# Altium schematic sheet 01.SchDoc
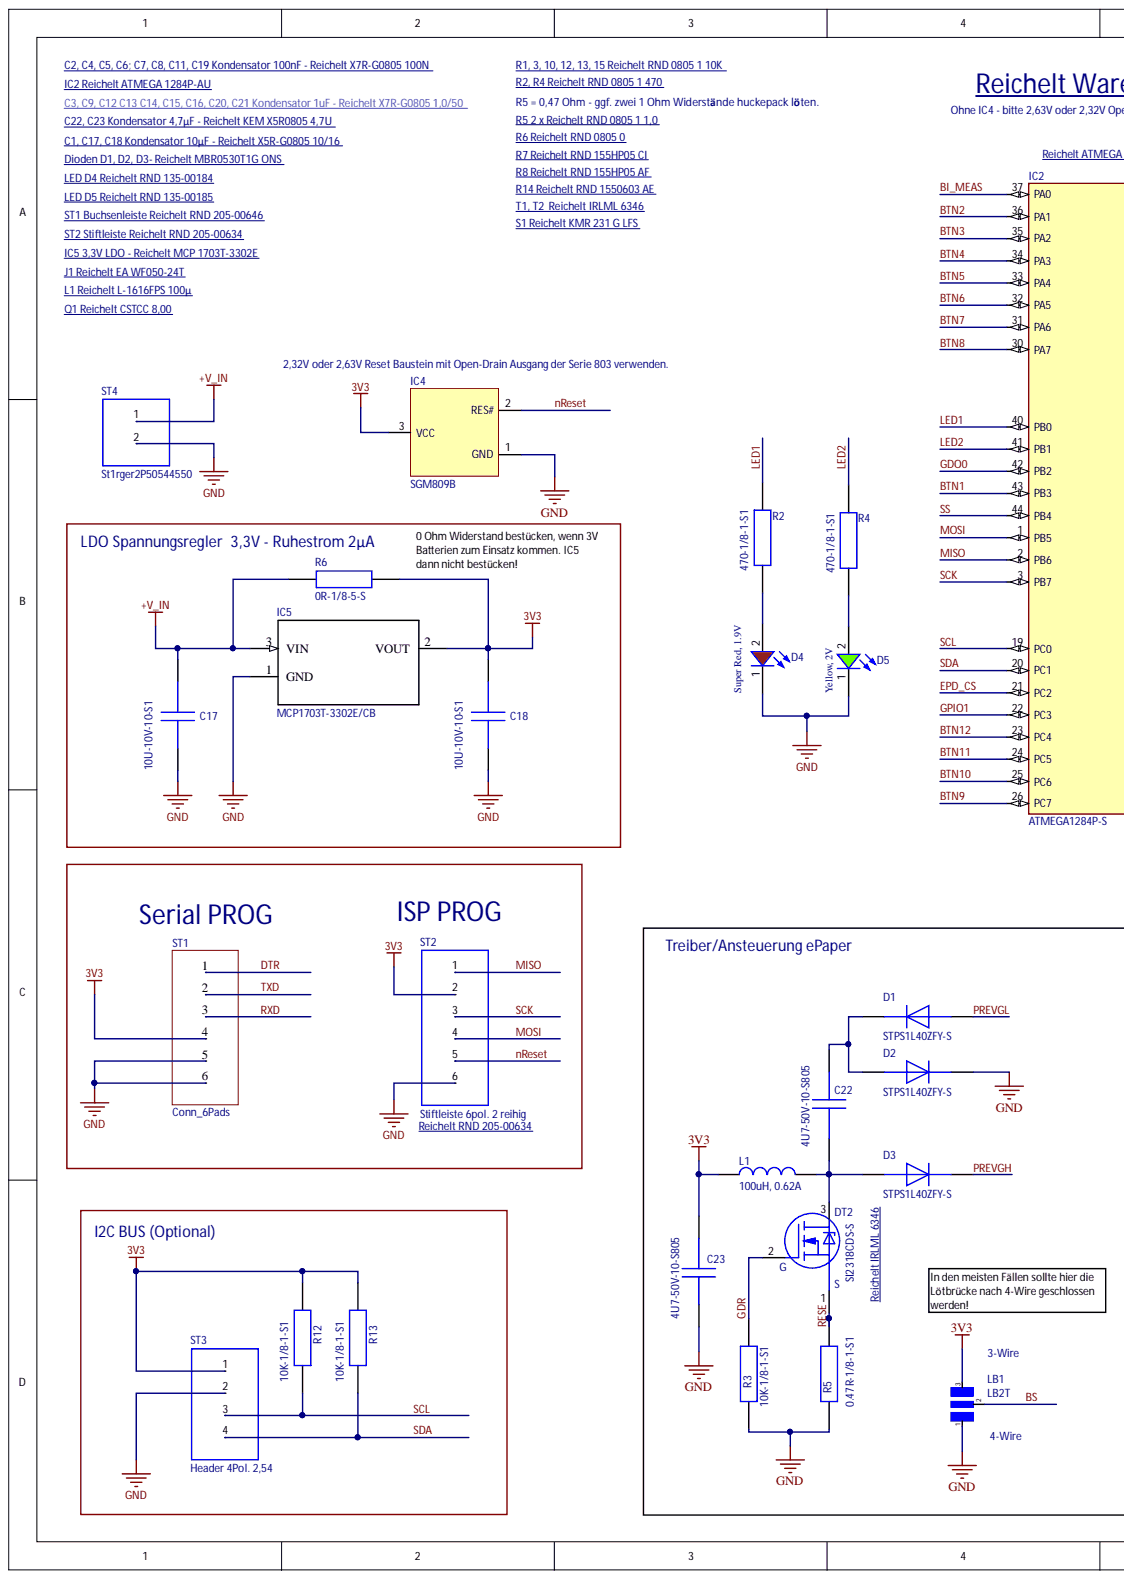
[diagram p0: 01.SchDoc, left]
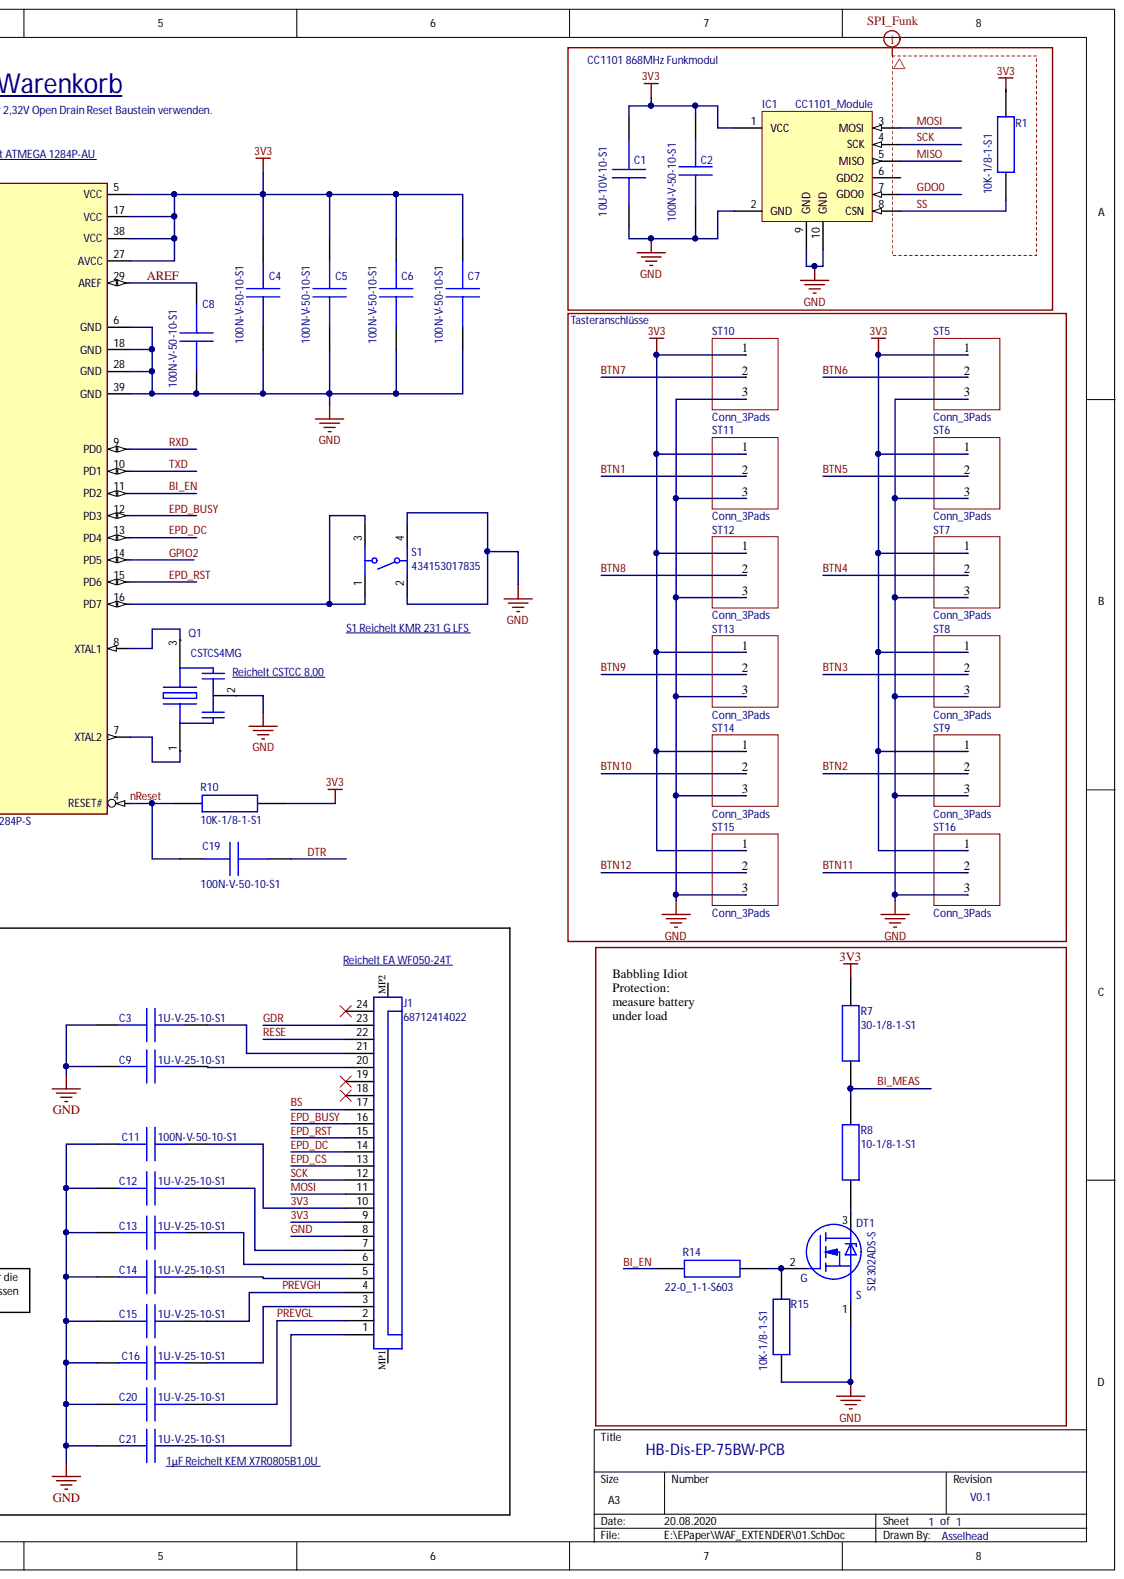
[diagram p1: 01.SchDoc, right]

## Parts
C1  10U-10V-10-S1  [Foot3816A]
C2  100N-V-50-10-S1  [Foot3816A]
C3  1U-V-25-10-S1  [Foot3816A]
C4  100N-V-50-10-S1  [Foot3816A]
C5  100N-V-50-10-S1  [Foot3816A]
C6  100N-V-50-10-S1  [Foot3816A]
C7  100N-V-50-10-S1  [Foot3816A]
C8  100N-V-50-10-S1  [Foot3816A]
C9  1U-V-25-10-S1  [Foot3816A]
C11  100N-V-50-10-S1  [Foot3816A]
C12  1U-V-25-10-S1  [Foot3816A]
C13  1U-V-25-10-S1  [Foot3816A]
C14  1U-V-25-10-S1  [Foot3816A]
C15  1U-V-25-10-S1  [Foot3816A]
C16  1U-V-25-10-S1  [Foot3816A]
C17  10U-10V-10-S1  [Foot3816A]
C18  10U-10V-10-S1  [Foot3816A]
C19  100N-V-50-10-S1  [Foot3816A]
C20  1U-V-25-10-S1  [Foot3816A]
C21  1U-V-25-10-S1  value 1µF  [Foot3816A]
C22  4U7-50V-10-S805  [0805_Capacitor 1,8mm Height]
C23  4U7-50V-10-S805  [0805_Capacitor 1,8mm Height]
D1  STPS1L40ZFY-S  [FootSOD123FL]
D2  STPS1L40ZFY-S  [FootSOD123FL]
D3  STPS1L40ZFY-S  [FootSOD123FL]
D4  Super Red, 1.9V  Forward Voltage typ.: 1.9V  [WL-SMCW_0805]
D5  Yellow, 2V  Forward Voltage typ.: 2V  [WL-SMCW_0805]
IC1  CC1101_Module  [CC1101_Module]
IC2  ATMEGA1284P-S  [Foot-TQFP44]
IC4  SGM809B  [Foot555A]
IC5  MCP1703T-3302E/CB  [SOT23-3]
J1  68712414022  [68712414022]
L1  100uH, 0.62A  Rated Current: 0.62A  [WE-LQS_5020]
LB1  LB2T  [Foot-LB2-0603]
Q1  CSTCS4MG  [Foot5411A]
R1  10K-1/8-1-S1  [Foot4417A]
R2  470-1/8-1-S1  [Foot4417A]
R3  10K-1/8-1-S1  [Foot4417A]
R4  470-1/8-1-S1  [Foot4417A]
R5  0.47R-1/8-1-S1  [Foot4417A]
R6  0R-1/8-5-S  value 0  [Foot4417A]
R7  30-1/8-1-S1  [Foot4417A]
R8  10-1/8-1-S1  [Foot4417A]
R10  10K-1/8-1-S1  [Foot4417A]
R12  10K-1/8-1-S1  [Foot4417A]
R13  10K-1/8-1-S1  [Foot4417A]
R14  22-0_1-1-S603  [Foot_Resistor_0603]
R15  10K-1/8-1-S1  [Foot4417A]
S1  434153017835  [434153017835]
ST1  Conn_6Pads  [Board_Contact6P]
ST2  Stiftleiste 6pol. 2 reihig  [Foot7387A]
ST3  Header 4Pol. 2,54  Pitch: 2,54  [Foot7331A]
ST4  St1rger2P50544550  [Foot7330A]
ST5  Conn_3Pads  [BOARD_CONTACT3P]
ST6  Conn_3Pads  [BOARD_CONTACT3P]
ST7  Conn_3Pads  [BOARD_CONTACT3P]
ST8  Conn_3Pads  [BOARD_CONTACT3P]
ST9  Conn_3Pads  [BOARD_CONTACT3P]
ST10  Conn_3Pads  [BOARD_CONTACT3P]
ST11  Conn_3Pads  [BOARD_CONTACT3P]
ST12  Conn_3Pads  [BOARD_CONTACT3P]
ST13  Conn_3Pads  [BOARD_CONTACT3P]
ST14  Conn_3Pads  [BOARD_CONTACT3P]
ST15  Conn_3Pads  [BOARD_CONTACT3P]
ST16  Conn_3Pads  [BOARD_CONTACT3P]
T1  SI2302ADS-S  [Foot8309A]
T2  SI2318CDS-S  [Foot8309A]

## Nets
+V_IN: C17.2 IC5.3 R6.1 ST4.1
3V3: C1.2 C2.1 C4.1 C5.1 C6.1 C7.1 C11.2 C18.2 C23.2 IC1.1(VCC) IC2.5(VCC) IC2.17(VCC) IC2.27(AVCC) IC2.38(VCC) IC4.3 IC5.2 J1.9 J1.10 L1.1 LB1.3 R1.2 R6.2 R7.2 R10.2 R12.2 R13.2 ST1.4 ST2.2 ST3.1 ST5.1 ST6.1 ST7.1 ST8.1 ST9.1 ST10.1 ST11.1 ST12.1 ST13.1 ST14.1 ST15.1 ST16.1
AREF: C8.1 IC2.29(AREF)
BI_EN: IC2.11(PD2) R14.1
BI_MEAS: IC2.37(PA0) R7.1 R8.2
BS: J1.17 LB1.2
BTN1: IC2.43(PB3) ST11.2
BTN2: IC2.36(PA1) ST9.2
BTN3: IC2.35(PA2) ST8.2
BTN4: IC2.34(PA3) ST7.2
BTN5: IC2.33(PA4) ST6.2
BTN6: IC2.32(PA5) ST5.2
BTN7: IC2.31(PA6) ST10.2
BTN8: IC2.30(PA7) ST12.2
BTN9: IC2.26(PC7) ST13.2
BTN10: IC2.25(PC6) ST14.2
BTN11: IC2.24(PC5) ST16.2
BTN12: IC2.23(PC4) ST15.2
DTR: C19.2 ST1.1
EPD_BUSY: IC2.12(PD3) J1.16
EPD_CS: IC2.21(PC2) J1.13
EPD_DC: IC2.13(PD4) J1.14
EPD_RST: IC2.15(PD6) J1.15
GDO0: IC1.7(GDO0) IC2.42(PB2)
GDR: J1.23 R3.1 T2.2
GND: C1.1 C2.2 C3.1 C4.2 C5.2 C6.2 C7.2 C8.2 C9.1 C11.1 C12.1 C13.1 C14.1 C15.1 C16.1 C17.1 C18.1 C20.1 C21.1 C23.1 D2.1 D4.1 D5.1 IC1.2(GND) IC1.9(GND) IC1.10(GND) IC2.6(GND) IC2.18(GND) IC2.28(GND) IC2.39(GND) IC4.1 IC5.1 J1.8 LB1.1 Q1.2 R3.2 R5.1 R15.1 S1.2 S1.4 ST1.5 ST1.6 ST2.6 ST3.2 ST4.2 ST5.3 ST6.3 ST7.3 ST8.3 ST9.3 ST10.3 ST11.3 ST12.3 ST13.3 ST14.3 ST15.3 ST16.3 T1.1
GPIO1: IC2.22(PC3)
GPIO2: IC2.14(PD5)
LED1: IC2.40(PB0) R2.1
LED2: IC2.41(PB1) R4.1
MISO: IC1.5(MISO) IC2.2(PB6) ST2.1
MOSI: IC1.3(MOSI) IC2.1(PB5) J1.11 ST2.4
nReset: C19.1 IC2.4(RESET#) IC4.2 R10.1 ST2.5
PREVGH: C15.2 D3.1 J1.4
PREVGL: C20.2 D1.2 J1.2
RESE: J1.22 R5.2 T2.1
RXD: IC2.9(PD0) ST1.3
SCK: IC1.4(SCK) IC2.3(PB7) J1.12 ST2.3
SCL: IC2.19(PC0) R12.1 ST3.3
SDA: IC2.20(PC1) R13.1 ST3.4
SS: IC1.8(CSN) IC2.44(PB4) R1.1
TXD: IC2.10(PD1) ST1.2
NetC3_2: C3.2 J1.21
NetC9_2: C9.2 J1.20
NetC12_2: C12.2 J1.7
NetC13_2: C13.2 J1.6
NetC14_2: C14.2 J1.5
NetC16_2: C16.2 J1.3
NetC21_2: C21.2 J1.1
NetC22_1: C22.1 D1.1 D2.2
NetC22_2: C22.2 D3.2 L1.2 T2.3
NetD4_2: D4.2 R2.2
NetD5_2: D5.2 R4.2
NetIC2_7: IC2.7(XTAL2) Q1.1
NetIC2_8: IC2.8(XTAL1) Q1.3
NetIC2_16: IC2.16(PD7) S1.1 S1.3
NetR8_1: R8.1 T1.3
NetR14_2: R14.2 R15.2 T1.2
N00033:

Not connected: IC1 6(GDO2); J1 18 19 24 MP1 MP2

## Library data of the parts
C1 C17 C18 = CMP-CAP-000494-2: SMD KONDENSATOR KERAMIK-VIELSCHICHT 10UF 10V 10% 0805; ArticleNumber: 50649650; MountingTech: SMT; Symbol Name: CAP, unpol.; Type: CERAMIC; Value: 10µF; Case/Package: 0805; Component Kind: Standard; ComponentLink1Description: Datasheet; ComponentLink1URL: http://cad-plotsvr.ads.local/View/SuB_Zukauf_Datenblaetter/50649650.pdf; Library Name: SuB AVault; neg# Tolerance: 10%; Pins: 2; Tolerance: 10%; Voltage: 10V
C2 C4-C8 C11 C19 = CMP-CAP-000448-2: SMD KONDENSATOR KERAMIK-VIELSCHICHT 100NF 50V 10% 805; ArticleNumber: 50640710; MountingTech: SMT; Symbol Name: CAP, unpol.; Type: CERAMIC; Case/Package: 0805; Component Kind: Standard; ComponentLink1Description: Datasheet; ComponentLink1URL: http://cad-plotsvr.ads.local/View/SuB_Zukauf_Datenblaetter/50640710.pdf; Library Name: SuB AVault; neg# Tolerance: 10%; Pins: 2; Tolerance: 10%; Value: 100nF; Voltage: 50V
C3 C9 C12-C16 C20 = CMP-CAP-000331-2: SMD KONDENSATOR KERAMIK-VIELSCHICHT 1UF 25V 10% 805; ArticleNumber: 50622750; MountingTech: SMT; Symbol Name: CAP, unpol.; Type: CERAMIC; Value: 1µF; Case/Package: 0805; Component Kind: Standard; ComponentLink1Description: Datasheet; ComponentLink1URL: http://cad-plotsvr.ads.local/View/SuB_Zukauf_Datenblaetter/50622750.pdf; Library Name: SuB AVault; neg# Tolerance: 10%; Pins: 2; Tolerance: 10%; Voltage: 25V
C21 = CMP-CAP-000331-2: SMD KONDENSATOR KERAMIK-VIELSCHICHT 1UF 25V 10% 805; ArticleNumber: 50622750; MountingTech: SMT; Symbol Name: CAP, unpol.; Type: CERAMIC; Case/Package: 0805; Component Kind: Standard; ComponentLink1Description: Datasheet; ComponentLink1URL: http://cad-plotsvr.ads.local/View/SuB_Zukauf_Datenblaetter/50622750.pdf; Library Name: SuB AVault; neg# Tolerance: 10%; Pins: 2; Tolerance: 10%; Voltage: 25V
C22 C23 = CMP-CAP-000601-2: KONDENSATOR 4,7UF 50V 10% X5R Case0805; ArticleNumber: 50674770; MountingTech: SMT; TempRange: -55°C to 85°C; Type: standard; Value: 4,7µF; Case/Package: 0805; Component Kind: Standard; ComponentLink1Description: Datasheet; ComponentLink1URL: http://cad-plotsvr.ads.local/Datasheet/50674770.pdf; Library Name: SuB AVault; Pins: 2; Tolerance: 10%; Voltage: 50V
D1-D3 = CMP-DIO-000270-3: SMD Schottky Diode 40V / 1A / 0,37V; ArticleNumber: 50669950; MountingTech: SMT; TempRange: -40°C - 175°C; Vf: 370mV; Case/Package: SOD-123FL; Component Kind: Standard; ComponentLink1Description: Datasheet; ComponentLink1URL: http://cad-plotsvr.ads.local/View/SuB_Zukauf_Datenblaetter/50669950.pdf; If: 1A; Library Name: SuB AVault; Pins: 2; Symbol Name: Diode; Type: SCHOTTKY; Vr: 40V
D4 = 150080SS75000: WL-SMCW SMT Mono-color Chip LED Waterclear, size 0805, Super Red, 1.9V, 140deg; Chip Technology: AlInGaP; Dominant Wavelength typ.: 630nm; Luminous Intensity typ.: 60mcd; Peak Wavelength typ.: 645nm; Component Kind: Standard; ComponentLink1Description: Manufacturer; ComponentLink1URL: http://www.we-online.de; ComponentLink2Description: Datasheet; ComponentLink2URL: https://www.we-online.com/catalog/datasheet/150080SS75000.pdf; Emitting Color: Super Red; Library Name: 150080SS75000_Altium_WL-SMCW_rev20a.IntLib; Mounting Technology: SMT; Operating Temperature: -40 to +85degC; Packaging: Tape and Reel; Part Number: 150080SS75000; Published Date: December 2019; Size: 0805; Viewing Angle: 140deg; Publisher: Wurth Elektronik
D5 = 150080VS75000: WL-SMCW SMT Mono-color Chip LED Waterclear, size 0805, Bright Green, 2V, 140deg; Chip Technology: AlInGaP; Dominant Wavelength typ.: 570nm; Luminous Intensity typ.: 40mcd; Peak Wavelength typ.: 572nm; Component Kind: Standard; ComponentLink1Description: Manufacturer; ComponentLink1URL: http://www.we-online.de; ComponentLink2Description: Datasheet; ComponentLink2URL: https://www.we-online.com/catalog/datasheet/150080VS75000.pdf; Emitting Color: Bright Green; Library Name: 150080SS75000_Altium_WL-SMCW_rev20a.IntLib; Mounting Technology: SMT; Operating Temperature: -40 to +85degC; Packaging: Tape and Reel; Part Number: 150080VS75000; Published Date: December 2019; Size: 0805; Viewing Angle: 140deg; Publisher: Wurth Elektronik
IC2 = CMP-IC-000916-1: FLASH MICROCONTROLLER; ArticleNumber: 50653560; MountingTech: SMT; Symbol Name: CONTR-ATmega644PA-S; Component Kind: Standard; ComponentLink1Description: Datasheet; ComponentLink1URL: http://cad-plotsvr.ads.local/View/SuB_Zukauf_Datenblaetter/50653560.pdf; Library Name: SuB AVault; Type: MC CONTROLLER
IC4 = CMP-IC-001339-2: UP RESET# MONITOR RES.TRES. 2,93V; ArticleNumber: 50604280; MountingTech: SMT; Name: MAX809SEUR-S; Symbol Name: SPANN3-0685-S; Component Kind: Standard; ComponentLink1Description: Datasheet; ComponentLink1URL: http://cad-plotsvr.ads.local/View/SuB_Zukauf_Datenblaetter/50604280.pdf; Library Name: SuB AVault; Type: VOLTAGE MONITORING
IC5 = MCP1703-3302: Component Kind: Standard; Library Name: ASKSIN.SchLib
J1 = 68712414022: WR-FPC SMT ZIF Horizontal Top Contact, pitch 0.5mm, 24p; Contact Resistance: 20mOhm; Pin Number: 24; Rated Current: 0.5A; Working Voltage: 50VAC; Component Kind: Standard; ComponentLink1Description: Manufacturer; ComponentLink1URL: http://www.we-online.de; ComponentLink2Description: Datasheet; ComponentLink2URL: https://katalog.we-online.com/em/datasheet/68712414022.pdf; Length: 18.4mm; Library Name: 68712414022_Altium_WR-FPC_rev19a.IntLib; Mounting Technology: SMT; Operating Temperature: -25 to +85degC; Packaging: Tape and Reel; Part Number: 68712414022; Pitch: 0.5mm; Published Date: November 2019; Type: Horizontal; Publisher: Wurth Elektronik
L1 = 74404052680: WE-LQS SMT Power Inductor, size 5020, 68uH, 0.62A; Manufacturer: Wurth Elektronik; DC Resistance: 769mOhm; Saturation Current: 0.8A; Self Resonant Frequency: 9.2MHz; Component Kind: Standard; ComponentLink1Description: Manufacturer; ComponentLink1URL: http://www.we-online.de; ComponentLink2Description: Datasheet; ComponentLink2URL: https://www.we-online.com/catalog/datasheet/74404052680.pdf; Inductance: 68uH; Library Name: 74404052680_Altium_WE-LQS_rev20b.IntLib; Manufacturer Part Number: 74404052680; Mounting Technology: SMT; Operating Temperature: -40 to +125degC; Packaging: Tape and Reel; Published Date: March 2020; Size: 5020
LB1 = CMP-GEN-000173-1: Solder Bridge 2 Positions; Value: 0; Component Kind: Standard; Library Name: SuB AVault; Pins: 0
Q1 = CMP-CLK-000056-1: SMD RESONATOR 4MHZ; ArticleNumber: 50596740; MountingTech: SMT; Symbol Name: SMD-RES; Type: QUARTZ; Component Kind: Standard; ComponentLink1Description: Datasheet; ComponentLink1URL: http://cad-plotsvr.ads.local/View/SuB_Zukauf_Datenblaetter/50596740.pdf; Library Name: SuB AVault
R1 R3 R10 R12 R13 R15 = CMP-RES-000430-3: WIDERSTAND 10K 1/8W 1% BAUF.805; ArticleNumber: 50602200; MountingTech: SMT; Power: 1/8; Symbol Name: Resistor; Case/Package: 0805; Component Kind: Standard; ComponentLink1Description: Datasheet; ComponentLink1URL: http://cad-plotsvr.ads.local/View/SuB_Zukauf_Datenblaetter/50602200.pdf; Library Name: SuB AVault; neg# Tolerance: 1%; Pins: 2; Tolerance: 1%; Type: STANDARD; Value: 10k
R2 R4 = CMP-RES-000426-3: WIDERSTAND 470R 1/8W 1% BAUF.805; ArticleNumber: 50602160; MountingTech: SMT; Power: 1/8; Symbol Name: Resistor; Value: 470; Case/Package: 0805; Component Kind: Standard; ComponentLink1Description: Datasheet; ComponentLink1URL: http://cad-plotsvr.ads.local/View/SuB_Zukauf_Datenblaetter/50602160.pdf; Library Name: SuB AVault; neg# Tolerance: 1%; Pins: 2; Tolerance: 1%; Type: STANDARD
R5 = CMP-RES-000493-4: WIDERSTAND R47 1/8W 1% BAUF.805; ArticleNumber: 50609420; MountingTech: SMT; Power: 1/8; Value: 0,47; Case/Package: 0805; Component Kind: Standard; ComponentLink1Description: Datasheet; ComponentLink1URL: http://cad-plotsvr.ads.local/View/SuB_Zukauf_Datenblaetter/50609420.pdf; Library Name: SuB AVault; neg# Tolerance: 1%; Pins: 2; Tolerance: 1%; Type: STANDARD
R6 = CMP-RES-000549-3: WIDERSTAND 0R 1/8W 5% BAUF.805; ArticleNumber: 50615000; MountingTech: SMT; Power: 1/8; Symbol Name: Resistor; Case/Package: 0805; Component Kind: Standard; ComponentLink1Description: Datasheet; ComponentLink1URL: http://cad-plotsvr.ads.local/View/SuB_Zukauf_Datenblaetter/50615000.pdf; Library Name: SuB AVault; neg# Tolerance: 5%; Pins: 2; Tolerance: 5%; Type: STANDARD
R7 = CMP-RES-000424-3: WIDERSTAND 33R 1/8W 1% BAUF.805; ArticleNumber: 50602140; MountingTech: SMT; Power: 1/8; Symbol Name: Resistor; Value: 33; Case/Package: 0805; Component Kind: Standard; ComponentLink1Description: Datasheet; ComponentLink1URL: http://cad-plotsvr.ads.local/View/SuB_Zukauf_Datenblaetter/50602140.pdf; Library Name: SuB AVault; neg# Tolerance: 1%; Pins: 2; Tolerance: 1%; Type: STANDARD
R8 = CMP-RES-000423-3: WIDERSTAND 10R 1/8W 1% BAUF.805; ArticleNumber: 50602130; MountingTech: SMT; Power: 1/8; Symbol Name: Resistor; Value: 10; Case/Package: 0805; Component Kind: Standard; ComponentLink1Description: Datasheet; ComponentLink1URL: http://cad-plotsvr.ads.local/View/SuB_Zukauf_Datenblaetter/50602130.pdf; Library Name: SuB AVault; neg# Tolerance: 1%; Pins: 2; Tolerance: 1%; Type: STANDARD
R14 = CMP-RES-000601-5: WIDERSTAND 22R 0,1W 1% BAUF.0603; ArticleNumber: 50624280; MountingTech: SMT; Power: 0,1; Symbol Name: Resistor; Value: 22; Case/Package: 0603; Component Kind: Standard; ComponentLink1Description: Datasheet; ComponentLink1URL: http://cad-plotsvr.ads.local/View/SuB_Zukauf_Datenblaetter/50624280.pdf; Library Name: SuB AVault; neg# Tolerance: 1%; Pins: 2; Tolerance: 1%; Type: STANDARD
S1 = 434153017835: WS-TASV J-Bend SMT Tact Switch 3.5x2.9mm, height 1.7mm, 350gf; Rated Current: 50mA; Rated Voltage: 12VDC; Washable: No; Component Kind: Standard; ComponentLink1Description: Manufacturer; ComponentLink1URL: http://www.we-online.de; ComponentLink2Description: Datasheet; ComponentLink2URL: https://katalog.we-online.com/em/datasheet/434153017835.pdf; Contact Material: Stainless Steel; Contact Plating: Silver; Height: 1.7mm; Library Name: ALTIUM-WS-TASV-rev19a.IntLib; Mounting Technology: SMT; Operating Temperature: -40 to +85degC; Operation Force: 350gf; Packaging: Tape and Reel; Part Number: 434153017835; Published Date: September 2019; Terminal Material: Copper Alloy; Terminal Plating: Silver; Publisher: Wurth Elektronik
ST2 = CMP-CON-000060-2: Stiftleiste 6pol. gerade 2-reihig von 5057719; ArticleNumber: 03308550; MountingTech: THT; Note: St2rger6P5057719; Pitch: 2,54; Rows: 2; Symbol Name: ST-6; Component Kind: Standard; ComponentLink1Description: Datasheet; ComponentLink1URL: http://cad-plotsvr.ads.local/View/SuB_Zukauf_Datenblaetter/03308550.pdf; Library Name: SuB AVault; Pins: 6; Type: PLUG STRAIGHT
ST3 = CMP-CON-000014-2: Header gerade 4 Pin von 5054455; ArticleNumber: 03268580; MountingTech: THT; Note: St1rger4P5054455; Rows: 1; Symbol Name: ST-4; Component Kind: Standard; ComponentLink1Description: Datasheet; ComponentLink1URL: http://cad-plotsvr.ads.local/View/SuB_Zukauf_Datenblaetter/03268580.pdf; Library Name: SuB AVault; Pins: 4; Type: PLUG STRAIGHT
ST4 = CMP-CON-000012-3: HEADER GERADE 2 PIN VON 50544550; ArticleNumber: 03268560; MountingTech: THT; Pitch: 2,54; Rows: 1; Symbol Name: ST-2; Component Kind: Standard; ComponentLink1Description: Datasheet; ComponentLink1URL: http://cad-plotsvr.ads.local/View/SuB_Zukauf_Datenblaetter/03268560.pdf; Library Name: SuB AVault; Note: St1rger2P50544550; Pins: 2; Type: PLUG STRAIGHT
T1 = CMP-TRS-000085-3: N-CHANNEL MOSFET Si2302ADS (SMD); ArticleNumber: 50612780; I-Drain: 2,4A; MountingTech: SMT; RDSON: 85m; Symbol Name: FET_N_1; TempRange: -55°C - 150°C; Type: FET N-CHANNEL; VDS: 20V; VGS: 4,5V; VGS(th): 950mV; Case/Package: SOT23; Component Kind: Standard; ComponentLink1Description: Datasheet; ComponentLink1URL: http://cad-plotsvr.ads.local/View/SuB_Zukauf_Datenblaetter/50612780.pdf; Library Name: SuB AVault; Pins: 3
T2 = CMP-TRS-000144-3: N-CHANNEL MOSFET SI2318CDS (SMD); ArticleNumber: 50648000; CISS: 340pF; I-Drain: 5,6A; MountingTech: SMT; RDSON: 42m; Symbol Name: FET_N_1; TempRange: -55°C - 150°C; Type: FET N-CHANNEL; VDS: 40V; VGS: 10V; VGS(th): 2,5V; Case/Package: SOT23; Component Kind: Standard; ComponentLink1Description: Datasheet; ComponentLink1URL: http://cad-plotsvr.ads.local/View/SuB_Zukauf_Datenblaetter/50648000.pdf; Library Name: SuB AVault; Pins: 3
IC1 = CC1101_Module: Component Kind: Standard; Library Name: ASKSIN.SchLib
ST1 = Conn_6Pads: Component Kind: Standard; Library Name: ASKSIN.SchLib
ST5-ST16 = Conn_3Pads: Component Kind: Standard; Library Name: ASKSIN.SchLib
